# Altium schematic sheet 02_RNWF UART to Cloud Add on Board .SchDoc("02_RNWF UART to Cloud Add on Board ") (continued)
## Library data of the parts (continued)
R25-R28 R32 R34 R45 R47 R51 R53 R56 R58 R60 R63 R64 = RES2436: Manufacturer 1: Yageo; Manufacturer Part Number 1: RC0402FR-0722RL; Description_: RES TKF 22R 1% 1/16W SMD 0402; Dev Tools Status: Production; Owner: Balaji Narayana; Populated: YES; Power: 1/16W; Temperature Coefficient: 100ppm/C; Tolerance: 1%; Category: Resistor; Component Kind: Standard; ComponentLink1Description: SVN Datasheet; ComponentLink1URL: https://chn-vm-apps.microchip.com/mchprepo/parts/Datasheets/RC0402FR-0722RL.pdf; ComponentLink2Description: Local Datasheet; ComponentLink3Description: Open Symbol; ComponentLink4Description: Open Footprint; ComponentLink5Description: Open Footprint 2; ComponentLink6Description: Open Footprint 3; ComponentLink7Description: Open Footprint 4; Composition: TKF; Database Table Name: Resistor; Description: Resistor; Library Name: MCHP-CDB.DbLib; MCHP-ID: RES2436; Operating Temperature: -55C to +155C; Package: 0402; Quantity Override: 1; Revision: 1; Status: MCL Design; Supplier 1: Digi-Key; Supplier Part Number 1: 311-22.0LRTR-ND; Symbol: Resistor; Type: RES SMD; ComponentLink2URL: C:\ALTIUM_WORK\00001_MCHP-CDB\Datasheets\RC0402FR-0722RL.pdf; ComponentLink3URL: C:\ALTIUM_WORK\00001_MCHP-CDB\Library\LibraryRefs\Resistor.SchLib; ComponentLink4URL: C:\ALTIUM_WORK\00001_MCHP-CDB\Library\Footprints\RES_C_0402.PcbLib
R29 R30 = RSMT0439: Manufacturer 1: Vishay; Manufacturer Part Number 1: CRCW040210K0JNED; Description_: RES TKF 10k 5% 1/16W SMD 0402; Dev Tools Status: Production; Power: 1/16W; Temperature Coeffcient: 100ppm/C; Voltage: 50V; Category: Resistor; Component Kind: Standard; ComponentLink1Description: SVN Datasheet; ComponentLink1URL: https://chn-vm-apps.microchip.com/mchprepo/parts/Datasheets/PYu-RC0402_51_RoHS_L_6.pdf; ComponentLink2Description: Local Datasheet; ComponentLink3Description: Open Symbol; ComponentLink4Description: Open Footprint; ComponentLink5Description: Open Footprint 2; ComponentLink6Description: Open Footprint 3; ComponentLink7Description: Open Footprint 4; Composition: TKF; Database Table Name: Resistor; Description: Resistor; Library Name: MCHP-CDB.DbLib; Manufacturer 2: Yageo; Manufacturer Part Number 2: RC0402JR-0710KL; MCHP-ID: RSMT0439; Operating Temperature: -55C to +155C; Quantity Override: 1; Revision: 1; Status: MCL Design; Supplier 1: Digi-Key; Supplier 2: Digi-Key; Supplier Part Number 1: 541-10KJCT-ND; Supplier Part Number 2: 311-10KJRCT-ND; Symbol: Resistor; Type: RES SMD; ComponentLink2URL: C:\ALTIUM_WORK\00001_MCHP-CDB\Datasheets\PYu-RC0402_51_RoHS_L_6.pdf; ComponentLink3URL: C:\ALTIUM_WORK\00001_MCHP-CDB\Library\LibraryRefs\Resistor.SchLib; ComponentLink4URL: C:\ALTIUM_WORK\00001_MCHP-CDB\Library\Footprints\RES_C_0402.PcbLib
R36 = RSMT1019: Manufacturer 1: Panasonic; Manufacturer Part Number 1: ERJ-2RKF1002X; Description_: RES TKF 10k 1% 1/10W SMD 0402; Owner: Brent Lanphear; Populated: YES; Power: 1/10W; Temperature Coeffcient: 100ppm/C; Voltage: 50V; Category: Resistor; Component Kind: Standard; ComponentLink1Description: Datasheet; ComponentLink1URL: https://chn-vm-apps.microchip.com/mchprepo/parts/Datasheets/AOA0000CE2.pdf; Composition: TKF; Database Table Name: Resistor; Description: Resistor; Library Name: MCHP-CDB.DbLib; MCHP-ID: RSMT1019; Operating Temperature: -55C to +155C; Quantity Override: 1; Revision: 2; Status: Production; Supplier 1: Digi-Key; Supplier Part Number 1: P10.0KLCT-ND; Type: RES SMD
R44 = RSMT1357: Manufacturer 1: Yageo; Manufacturer Part Number 1: RC0201FR-071KL; Description_: RES TKF 1k 1% 1/20W SMD 0201; Dev Tools Status: Production; Owner: Ciprian Abramov; Populated: YES; Power: 1/20W; Restricted Sourcing: No; Temperature Coefficient: 200ppm/C; Voltage: 25V; Category: Resistor; Component Kind: Standard; ComponentLink1Description: SVN Datasheet; ComponentLink1URL: https://chn-vm-apps.microchip.com/mchprepo/parts/Datasheets/PYu-RC_Group_51_RoHS_L_11.pdf; ComponentLink2Description: Local Datasheet; ComponentLink3Description: Open Symbol; ComponentLink4Description: Open Footprint; ComponentLink5Description: Open Footprint 2; ComponentLink6Description: Open Footprint 3; ComponentLink7Description: Open Footprint 4; Composition: TKF; Database Table Name: Resistor; Description: Resistor; Library Name: MCHP-CDB.DbLib; MCHP-ID: RSMT1357; Operating Temperature: -55C to +125C; Quantity Override: 1; Revision: 1; Status: MCL Design; Supplier 1: Digi-Key; Supplier Part Number 1: 311-1KMCT-ND; Symbol: Resistor; Type: RES SMD; ComponentLink2URL: C:\ALTIUM_WORK\00001_MCHP-CDB\Datasheets\PYu-RC_Group_51_RoHS_L_11.pdf; ComponentLink3URL: C:\ALTIUM_WORK\00001_MCHP-CDB\Library\LibraryRefs\Resistor.SchLib; ComponentLink4URL: C:\ALTIUM_WORK\00001_MCHP-CDB\Library\Footprints\RES_C_0201.PcbLib
R62 = RES1552: Manufacturer 1: Panasonic Electronic Components; Manufacturer Part Number 1: ERJ-2RKF33R0X; Description_: RES TKF 33R 1% 1/10W SMD 0402; Dev Tools Status: Production; Owner: Sorin Stanoaia; Populated: YES; Power: 1/10W; Temperature Coefficient: 100ppm/C; Tolerance: 1%; Category: Resistor; Component Kind: Standard; ComponentLink1Description: SVN Datasheet; ComponentLink1URL: https://chn-vm-apps.microchip.com/mchprepo/parts/Datasheets/AOA0000CE2.pdf; ComponentLink2Description: Local Datasheet; ComponentLink3Description: Open Symbol; ComponentLink4Description: Open Footprint; ComponentLink5Description: Open Footprint 2; ComponentLink6Description: Open Footprint 3; ComponentLink7Description: Open Footprint 4; Composition: TKF; Database Table Name: Resistor; Description: Resistor; Library Name: MCHP-CDB.DbLib; MCHP-ID: RES1552; Operating Temperature: -55C to +155C; Package: 0402; Quantity Override: 1; Revision: 1; Status: MCL Design; Supplier 1: Digi-Key; Supplier Part Number 1: P33.0LCT-ND; Symbol: Resistor; Type: RES SMD; ComponentLink2URL: C:\ALTIUM_WORK\00001_MCHP-CDB\Datasheets\AOA0000CE2.pdf; ComponentLink3URL: C:\ALTIUM_WORK\00001_MCHP-CDB\Library\LibraryRefs\Resistor.SchLib; ComponentLink4URL: C:\ALTIUM_WORK\00001_MCHP-CDB\Library\Footprints\RES_C_0402.PcbLib
R67 = IND1735: Manufacturer 1: Murata Electronics; Manufacturer Part Number 1: BLM15AG121SN1D; DCR: 0.19R; Description_: FERRITE 120R @ 100MHz 0.55A 0.19R SMD 0402; Dev Tools Status: Design; Owner: Jojie Batislaon; Populated: YES; RMS Current: 0.55A; Test Frequency: 100MHz; Category: Inductor; Component Kind: Standard; ComponentLink1Description: SVN Datasheet; ComponentLink1URL: https://chn-vm-apps.microchip.com/mchprepo/parts/Datasheets/ENFA0018.pdf; ComponentLink2Description: Local Datasheet; ComponentLink3Description: Open Symbol; ComponentLink4Description: Open Footprint; ComponentLink5Description: Open Footprint 2; ComponentLink6Description: Open Footprint 3; ComponentLink7Description: Open Footprint 4; Database Table Name: Inductor; Description: Inductor; Library Name: MCHP-CDB.DbLib; MCHP-ID: IND1735; Operating Temperature: -55C to +125C; Package: 0402; Quantity Override: 1; Revision: 1; Status: MCL Design; Supplier 1: Digi-Key; Supplier Part Number 1: 490-1005-2-ND; Symbol: Inductor; Type: Ferrite Bead; ComponentLink2URL: C:\ALTIUM_WORK\00001_MCHP-CDB\Datasheets\ENFA0018.pdf; ComponentLink3URL: C:\ALTIUM_WORK\00001_MCHP-CDB\Library\LibraryRefs\Inductor.SchLib; ComponentLink4URL: C:\ALTIUM_WORK\00001_MCHP-CDB\Library\Footprints\IND_C_0402.PcbLib
R69 R88 R89 = RES2705: Manufacturer 1: Yageo; Manufacturer Part Number 1: RC0201FR-070RL; Current: 500mA; Description_: RES TKF 0R 1/20W SMD 0201; Dev Tools Status: Design; Owner: Jojie Batislaon; Populated: YES; Power: 1/20W; Restricted Sourcing: No; Voltage: 25V; Category: Resistor; Component Kind: Standard; ComponentLink1Description: SVN Datasheet; ComponentLink1URL: https://chn-vm-apps.microchip.com/mchprepo/parts/Datasheets/PYu-RC_Group_51_RoHS_L_11.pdf; ComponentLink2Description: Local Datasheet; ComponentLink3Description: Open Symbol; ComponentLink4Description: Open Footprint; ComponentLink5Description: Open Footprint 2; ComponentLink6Description: Open Footprint 3; ComponentLink7Description: Open Footprint 4; Composition: TKF; Database Table Name: Resistor; Description: Resistor; Library Name: MCHP-CDB.DbLib; MCHP-ID: RES2705; Operating Temperature: -55C to +125C; Quantity Override: 1; Revision: A; Status: MCL Design; Supplier 1: Digi-Key; Supplier Part Number 1: 311-0.0MTR-ND; Symbol: Resistor; Type: RES SMD; ComponentLink2URL: C:\ALTIUM_WORK\00001_MCHP-CDB\Datasheets\PYu-RC_Group_51_RoHS_L_11.pdf; ComponentLink3URL: C:\ALTIUM_WORK\00001_MCHP-CDB\Library\LibraryRefs\Resistor.SchLib; ComponentLink4URL: C:\ALTIUM_WORK\00001_MCHP-CDB\Library\Footprints\RES_C_0201.PcbLib
R71 R72 = RES1496: Manufacturer 1: Panasonic; Manufacturer Part Number 1: ERJ-2RKF2000X; Description_: RES TKF 200R 1% 1/10W SMD 0402; Dev Tools Status: Production; Populated: YES; Power: 1/10W; Temperature Coeffcient: 200ppm/C; Tolerance: 1%; Voltage: 50V; Category: Resistor; Component Kind: Standard; ComponentLink1Description: SVN Datasheet; ComponentLink1URL: https://chn-vm-apps.microchip.com/mchprepo/parts/Datasheets/AOA0000CE1.pdf; ComponentLink2Description: Local Datasheet; ComponentLink3Description: Open Symbol; ComponentLink4Description: Open Footprint; ComponentLink5Description: Open Footprint 2; ComponentLink6Description: Open Footprint 3; ComponentLink7Description: Open Footprint 4; Composition: TKF; Database Table Name: Resistor; Description: Resistor; Library Name: MCHP-CDB.DbLib; MCHP-ID: RES1496; Operating Temperature: -55C to +155C; Package: 0402; Quantity Override: 1; Revision: 1; Status: MCL Design; Supplier 1: Digi-Key; Supplier Part Number 1: P200LTR-ND; Symbol: Resistor; Type: RES SMD; ComponentLink2URL: C:\ALTIUM_WORK\00001_MCHP-CDB\Datasheets\AOA0000CE1.pdf; ComponentLink3URL: C:\ALTIUM_WORK\00001_MCHP-CDB\Library\LibraryRefs\Resistor.SchLib; ComponentLink4URL: C:\ALTIUM_WORK\00001_MCHP-CDB\Library\Footprints\RES_C_0402.PcbLib
R73-R77 = RSMT1040: Resistor; Manufacturer 1: Yageo; Manufacturer Part Number 1: RC0402JR-070RL; Description_: RES TKF 0R 1/16W SMD 0402; Dev Tools Status: Production; Owner: Sompoch Loboonlert; Power: 1/16W; Category: Resistor; Component Kind: Standard; ComponentLink1Description: SVN Datasheet; ComponentLink1URL: https://chn-vm-apps.microchip.com/mchprepo/parts/Datasheets/PYu-RC0402_51_RoHS_L_6.pdf; ComponentLink2Description: Local Datasheet; ComponentLink3Description: Open Symbol; ComponentLink4Description: Open Footprint; ComponentLink5Description: Open Footprint 2; ComponentLink6Description: Open Footprint 3; ComponentLink7Description: Open Footprint 4; Composition: TKF; Database Table Name: Resistor; Library Name: MCHP-CDB.DbLib; Manufacturer 2: Stackpole Electronics Inc; Manufacturer Part Number 2: RMCF0402ZT0R00; MCHP-ID: RSMT1040; Operating Temperature: -55C to +155C; Package: 0402; Quantity Override: 1; Revision: 3; Status: MCL Design; Supplier 1: Digi-Key; Supplier 2: Digi-Key; Supplier Part Number 1: 311-0.0JRCT-ND; Supplier Part Number 2: RMCF0402ZT0R00TR-ND; Symbol: Resistor; Type: RES SMD; ComponentLink2URL: C:\ALTIUM_WORK\00001_MCHP-CDB\Datasheets\PYu-RC0402_51_RoHS_L_6.pdf; ComponentLink3URL: C:\ALTIUM_WORK\00001_MCHP-CDB\Library\LibraryRefs\Resistor.SchLib; ComponentLink4URL: C:\ALTIUM_WORK\00001_MCHP-CDB\Library\Footprints\RES_C_0402.PcbLib
R78 = RES2436: Manufacturer 1: Yageo; Manufacturer Part Number 1: RC0402FR-0722RL; Description_: RES TKF 22R 1% 1/16W SMD 0402; Dev Tools Status: Production; Owner: Balaji Narayana; Populated: DNP; Power: 1/16W; Temperature Coefficient: 100ppm/C; Category: Resistor; Component Kind: Standard; ComponentLink1Description: SVN Datasheet; ComponentLink1URL: https://chn-vm-apps.microchip.com/mchprepo/parts/Datasheets/RC0402FR-0722RL.pdf; ComponentLink2Description: Local Datasheet; ComponentLink3Description: Open Symbol; ComponentLink4Description: Open Footprint; ComponentLink5Description: Open Footprint 2; ComponentLink6Description: Open Footprint 3; ComponentLink7Description: Open Footprint 4; Composition: TKF; Database Table Name: Resistor; Description: Resistor; Library Name: MCHP-CDB.DbLib; MCHP-ID: RES2436; Operating Temperature: -55C to +155C; Package: 0402; Quantity Override: 1; Revision: 1; Status: MCL Design; Supplier 1: Digi-Key; Supplier Part Number 1: 311-22.0LRTR-ND; Symbol: Resistor; Type: RES SMD; ComponentLink2URL: C:\ALTIUM_WORK\00001_MCHP-CDB\Datasheets\RC0402FR-0722RL.pdf; ComponentLink3URL: C:\ALTIUM_WORK\00001_MCHP-CDB\Library\LibraryRefs\Resistor.SchLib; ComponentLink4URL: C:\ALTIUM_WORK\00001_MCHP-CDB\Library\Footprints\RES_C_0402.PcbLib
R79 = RES1552: Manufacturer 1: Panasonic Electronic Components; Manufacturer Part Number 1: ERJ-2RKF33R0X; Description_: RES TKF 33R 1% 1/10W SMD 0402; Dev Tools Status: Production; Owner: Sorin Stanoaia; Power: 1/10W; Temperature Coeffcient: 100ppm/C; Tolerance: 1%; Category: Resistor; Component Kind: Standard; ComponentLink1Description: SVN Datasheet; ComponentLink1URL: https://chn-vm-apps.microchip.com/mchprepo/parts/Datasheets/AOA0000CE2.pdf; ComponentLink2Description: Local Datasheet; ComponentLink3Description: Open Symbol; ComponentLink4Description: Open Footprint; ComponentLink5Description: Open Footprint 2; ComponentLink6Description: Open Footprint 3; ComponentLink7Description: Open Footprint 4; Composition: TKF; Database Table Name: Resistor; Description: Resistor; Library Name: MCHP-CDB.DbLib; MCHP-ID: RES1552; Operating Temperature: -55C to +155C; Package: 0402; Quantity Override: 1; Revision: 1; Status: MCL Design; Supplier 1: Digi-Key; Supplier Part Number 1: P33.0LCT-ND; Symbol: Resistor; Temperature Coefficient: 100ppm/C; Type: RES SMD; ComponentLink2URL: C:\ALTIUM_WORK\00001_MCHP-CDB\Datasheets\AOA0000CE2.pdf; ComponentLink3URL: C:\ALTIUM_WORK\00001_MCHP-CDB\Library\LibraryRefs\Resistor.SchLib; ComponentLink4URL: C:\ALTIUM_WORK\00001_MCHP-CDB\Library\Footprints\RES_C_0402.PcbLib
R80 = RES0191: Resistor; Manufacturer 1: Panasonic; Manufacturer Part Number 1: ERJ-3GEYJ103V; Description_: RES TKF 10k 5% 1/10W SMD 0603; Dev Tools Status: Production; Populated: YES; Power: 1/10W; Temperature Coeffcient: 200ppm/C; Voltage: 75V; Category: Resistor; Component Kind: Standard; ComponentLink1Description: SVN Datasheet; ComponentLink1URL: https://chn-vm-apps.microchip.com/mchprepo/parts/Datasheets/AOA0000CE1.pdf; ComponentLink2Description: Local Datasheet; ComponentLink3Description: Open Symbol; ComponentLink4Description: Open Footprint; ComponentLink5Description: Open Footprint 2; ComponentLink6Description: Open Footprint 3; ComponentLink7Description: Open Footprint 4; Composition: TKF; Database Table Name: Resistor; Library Name: MCHP-CDB.DbLib; Manufacturer 2: Yageo; Manufacturer 3: NIC Components; Manufacturer Part Number 2: RC0603JR-0710KL; Manufacturer Part Number 3: NRC06J103TRF; MCHP-ID: RES0191; Operating Temperature: -55C to +155C; Quantity Override: 1; Revision: 2; Status: MCL Design; Supplier 1: Digi-Key; Supplier 2: Digi-Key; Supplier 3: Digi-Key; Supplier 4: NIC Components; Supplier Part Number 1: P10KGCT-ND; Supplier Part Number 2: P10KGTR-ND; Supplier Part Number 3: 311-10KGRTR-ND; Supplier Part Number 4: NRC06J103TRF; Symbol: Resistor; Type: RES SMD; ComponentLink2URL: C:\ALTIUM_WORK\00001_MCHP-CDB\Datasheets\AOA0000CE1.pdf; ComponentLink3URL: C:\ALTIUM_WORK\00001_MCHP-CDB\Library\LibraryRefs\Resistor.SchLib; ComponentLink4URL: C:\ALTIUM_WORK\00001_MCHP-CDB\Library\Footprints\RES_C_0603.PcbLib
R81 R85 = RES2977: Manufacturer 1: Panasonic Electronic Components; Manufacturer Part Number 1: ERJ-2GEJ122X; Description_: RES TKF 1.2k 5% 0.1W SMD 0402; Dev Tools Status: Design; Owner: Balaji Narayana; Populated: YES; Power: 1/10W; Temperature Coefficient: 200ppm/C; Category: Resistor; Component Kind: Standard; ComponentLink1Description: SVN Datasheet; ComponentLink1URL: https://chn-vm-apps.microchip.com/mchprepo/parts/Datasheets/ERJ-2GEJ122X.pdf; ComponentLink2Description: Local Datasheet; ComponentLink3Description: Open Symbol; ComponentLink4Description: Open Footprint; ComponentLink5Description: Open Footprint 2; ComponentLink6Description: Open Footprint 3; ComponentLink7Description: Open Footprint 4; Composition: TKF; Database Table Name: Resistor; Description: Resistor; Library Name: MCHP-CDB.DbLib; MCHP-ID: RES2977; Operating Temperature: -55C to +155C; Quantity Override: 1; Revision: 1; Status: MCL Design; Supplier 1: Digikey; Supplier Part Number 1: P1.2KJTR-ND; Symbol: Resistor; Type: RES SMD; ComponentLink2URL: C:\ALTIUM_WORK\00001_MCHP-CDB\Datasheets\ERJ-2GEJ122X.pdf; ComponentLink3URL: C:\ALTIUM_WORK\00001_MCHP-CDB\Library\LibraryRefs\Resistor.SchLib; ComponentLink4URL: C:\ALTIUM_WORK\00001_MCHP-CDB\Library\Footprints\RES_C_0402.PcbLib
R82 R83 = RSMT1019: Resistor; Manufacturer 1: Panasonic; Manufacturer Part Number 1: ERJ-2RKF1002X; Description_: RES TKF 10k 1% 1/10W SMD 0402; Dev Tools Status: Production; Owner: Brent Lanphear; Populated: YES; Power: 1/10W; Temperature Coefficient: 100ppm/C; Voltage: 50V; Category: Resistor; Component Kind: Standard; ComponentLink1Description: SVN Datasheet; ComponentLink1URL: https://chn-vm-apps.microchip.com/mchprepo/parts/Datasheets/AOA0000CE2.pdf; ComponentLink2Description: Local Datasheet; ComponentLink3Description: Open Symbol; ComponentLink4Description: Open Footprint; ComponentLink5Description: Open Footprint 2; ComponentLink6Description: Open Footprint 3; ComponentLink7Description: Open Footprint 4; Composition: TKF; Database Table Name: Resistor; Library Name: MCHP-CDB.DbLib; MCHP-ID: RSMT1019; Operating Temperature: -55C to +155C; Quantity Override: 1; Revision: 2; Status: MCL Design; Supplier 1: Digi-Key; Supplier Part Number 1: P10.0KLCT-ND; Symbol: Resistor; Type: RES SMD; ComponentLink2URL: C:\ALTIUM_WORK\00001_MCHP-CDB\Datasheets\AOA0000CE2.pdf; ComponentLink3URL: C:\ALTIUM_WORK\00001_MCHP-CDB\Library\LibraryRefs\Resistor.SchLib; ComponentLink4URL: C:\ALTIUM_WORK\00001_MCHP-CDB\Library\Footprints\RES_C_0402.PcbLib
TP2 TP3 = CON1956: Test Point; Database Table Name: Connector; Description_: CON TP PAD PCB SMD D0.8; Dev Tools Status: Design; Owner: Mark Loper; Populated: YES; Category: Connector; ComponentLink1Description: SVN Datasheet; ComponentLink2Description: Local Datasheet; ComponentLink3Description: Open Symbol; ComponentLink4Description: Open Footprint; ComponentLink5Description: Open Footprint 2; ComponentLink6Description: Open Footprint 3; ComponentLink7Description: Open Footprint 4; Library Name: MCHP-CDB.DbLib; MCHP-ID: CON1956; Quantity Override: 1; Revision: 1; Status: MCL Design; Symbol: Test Point No BOM; Type: Test Point No BOM; ComponentLink3URL: C:\ALTIUM_WORK\00001_MCHP-CDB\Library\LibraryRefs\Test Point No BOM.SchLib; ComponentLink4URL: C:\ALTIUM_WORK\00001_MCHP-CDB\Library\Footprints\CON_TP_0.8dia_SMD.PcbLib
U1 = MIC2631: LDO ADJ; Manufacturer 1: Microchip; Manufacturer Part Number 1: MCP1727T-ADJE/MF; Database Table Name: MCHP-Analog; Description_: MCHP ANALOG LDO 0.8V-5V MCP1727T-ADJE/MF DFN-8; Dev Tools Status: Production; ForceMinOrderQtyMult: True; LeadContent: Pb-FREE; LeadCount: 8; MAPS Description: 1.5A LOW VOLTAGE LDO; MinOrderQty: 1; MPC: GEAG1YA7XADJ; PackageWidth: 3x3x0.9mm; PackingMedia: T/R; Populated: YES; Stage: REL; tblDeviceFamilyID: 1674; TempRange: -40C to +125C; Category: MCHP-Analog; Component Kind: Standard; ComponentLink1Description: SVN Datasheet; ComponentLink1URL: http://www.microchip.com/wwwproducts/MCP1727; ComponentLink2Description: Local Datasheet; ComponentLink3Description: Open Symbol; ComponentLink4Description: Open Footprint; ComponentLink5Description: Open Footprint 2; ComponentLink6Description: Open Footprint 3; ComponentLink7Description: Open Footprint 4; IntroductionDate: 2006-08-02; Library Name: MCHP-CDB.DbLib; Manufacturer 2: Microchip; Manufacturer Part Number 2: MCP1727-ADJE/MF; MCHP-ID: MIC2631; PackageType: DFN; Quantity Override: 1; Revision: 0; Status: MCL Design; Supplier 1: Microchip; Supplier 2: Microchip; Supplier Part Number 1: MCP1727T-ADJE/MF; Supplier Part Number 2: MCP1727-ADJE/MF; Symbol: MCP172X ADJ DFN-8; Type: LDO; ComponentLink3URL: C:\ALTIUM_WORK\00001_MCHP-CDB\Library\LibraryRefs\MCP172X ADJ DFN-8.SchLib; ComponentLink4URL: C:\ALTIUM_WORK\00001_MCHP-CDB\Library\Footprints\DFN-8_3x3.PcbLib
U2 = MIC6326: USB 2.0 to UART Protocol Conv with GPIO QFN20; Manufacturer: Microchip Technology; Database Table Name: MCHP-Interface; Description_: MCHP INTERFACE USB UART MCP2200-I/MQ QFN-20; Dev Tools Status: Production; EEPROM: 256; ForceMinOrderQtyMult: False; GPIO: 8; LeadContent: Pb-FREE; LeadCount: 20; MAPS Description: USB to UART Protocol Converter; MinOrderQty: 1; MPC: LEAG17P8X026; OperatingVoltageMax: 5.5; OperatingVoltageMin: 3.0; oscillator: External; Owner: Jamus Griego; PackageWidth: 5x5x0.9mm; PackingMedia: TUBE; Populated: YES; RxBuffers: 128; Stage: REL; tblDeviceFamilyID: 2705; TempRange: -40C to +85C; USB: Full Speed; usbcompliant: USB 2.0; Voltage: 3V ~ 5.5V; Category: MCHP-Interface; Component Kind: Standard; ComponentLink1Description: SVN Datasheet; ComponentLink1URL: http://www.microchip.com/wwwproducts/MCP2200; ComponentLink2Description: Local Datasheet; ComponentLink3Description: Open Symbol; ComponentLink4Description: Open Footprint; ComponentLink5Description: Open Footprint 2; ComponentLink6Description: Open Footprint 3; ComponentLink7Description: Open Footprint 4; Features: USB to UART; FIFO's: -; IntroductionDate: 2010-03-01; Library Name: MCHP-CDB.DbLib; Manufacturer 1: Microchip Technology; Manufacturer Part Number 1: MCP2200-I/MQ; MCHP-ID: MIC6326; Mounting Type: Surface Mount; Number of Channels: 8; Package Size: 20-VQFN, Exposed Pad; PackageType: QFN; Packaging: Tube; Pricing 1: 1=2.3, 10=2.1, 25=1.75, 100=1.59 (USD); Quantity Override: 1; Revision: 1; RoHS: RoHS Compliant; Status: MCL Design; Stock 1: 839; Supplier 1: Digi-Key; Supplier Part Number 1: MCP2200-I/MQ-ND; Symbol: MCP2200 QFN-20; TxBuffers: 128; Type: USB; ComponentLink3URL: C:\ALTIUM_WORK\00001_MCHP-CDB\Library\LibraryRefs\MCP2200 QFN-20.SchLib; ComponentLink4URL: C:\ALTIUM_WORK\00001_MCHP-CDB\Library\Footprints\QFN-20_5X5.PcbLib
U3 = MIC9887: Manufacturer 1: Microchip Technology; Database Table Name: MCHP-Wireless; Description_: PIC32MZ1025W1, PCB Ant, Trust & GO, firmware version 001; Dev Tools Status: Production; Owner: Balaji Narayana; Populated: YES; Restricted Sourcing: No; Category: MCHP-Wireless; Component Kind: Standard; ComponentLink1Description: SVN Datasheet; ComponentLink1URL: https://www.microchip.com/sitesearch/search/Product%20and%20Development%20Tools/RNWF11PC-I100; ComponentLink2Description: Local Datasheet; ComponentLink3Description: Open Symbol; ComponentLink4Description: Open Footprint; ComponentLink5Description: Open Footprint 2; ComponentLink6Description: Open Footprint 3; ComponentLink7Description: Open Footprint 4; Library Name: MCHP-CDB.DbLib; MCHP-ID: MIC9887; Operating Temperature: -40C to +85C; Quantity Override: 1; Revision: 1; Status: MCL Design; Supplier 1: Microchip Technology; Supplier Part Number 1: RNWF11PC-I100; Symbol: MODULE RNWF11PC-I; Type: Wi-Fi; ComponentLink3URL: C:\ALTIUM_WORK\00001_MCHP-CDB\Library\LibraryRefs\MODULE RNWF11PC-I.SchLib; ComponentLink4URL: C:\ALTIUM_WORK\00001_MCHP-CDB\Library\Footprints\MOD_RNWF11PC-I.PcbLib
U4 = MIC9023: Manufacturer 1: Microchip Technology; Manufacturer Part Number 1: ATECC608B-MAHA6-S; Database Table Name: MCHP-Interface; Description_: MCHP INTERFACE SECURITY I2C ATECC608B-TFLXTLS UDFN-8; Dev Tools Status: Production; Owner: James Boomer; Populated: YES; Symbol: ATECC608B-MAHDA UDFN-8; Category: MCHP-Interface; Component Kind: Standard; ComponentLink1Description: SVN Datasheet; ComponentLink1URL: https://www.microchip.com/sitesearch/search/Product%20and%20Development%20Tools/ATECC608B-MAHA6-S; ComponentLink2Description: Local Datasheet; ComponentLink3Description: Open Symbol; ComponentLink4Description: Open Footprint; ComponentLink5Description: Open Footprint 2; ComponentLink6Description: Open Footprint 3; ComponentLink7Description: Open Footprint 4; Library Name: MCHP-CDB.DbLib; MCHP-ID: MIC9023; Operating Temperature: -40C to +85C; Quantity Override: 1; Revision: 4; Status: MCL Design; Supplier 1: Microchip Technology; Supplier Part Number 1: ATECC608B-MAHA6-S; ComponentLink3URL: C:\ALTIUM_WORK\00001_MCHP-CDB\Library\LibraryRefs\ATECC608B-MAHDA UDFN-8.SchLib; ComponentLink4URL: C:\ALTIUM_WORK\00001_MCHP-CDB\Library\Footprints\UDFN-8_2X3_EP1.5X1.3.PcbLib
U5 = MIC6217: SQI Serial Flash; Manufacturer 1: Microchip Technology; Manufacturer Part Number 1: SST26VF032B-104I/SM; Database Table Name: MCHP-Memory; Description_: MCHP MEMORY SERIAL FLASH SST26VF032B-104I/SM SOIJ-8; Dev Tools Status: Production; Endurance: 100,000; ForceMinOrderQtyMult: False; LeadContent: Pb-FREE; LeadCount: 8; MAPS Description: 32Mbit SQI flash; MaxClockFreq: 104 MHz; MemorySize: 32Mb; MinOrderQty: 0; MPC: S01017C3XA23; OpVoltMax: 3.6; OpVoltMin: 2.3; Owner: Sompoch Loboonlert; PackageWidth: .208in; PackingMedia: TUBE; PageSize_B: 256; Populated: YES; Stage: REL; TempRange: -40C to +85C; WPScheme: Full Array; Category: MCHP-Memory; Component Kind: Standard; ComponentLink1Description: SVN Datasheet; ComponentLink1URL: http://www.microchip.com/wwwproducts/SST26VF032B; ComponentLink2Description: Local Datasheet; ComponentLink3Description: Open Symbol; ComponentLink4Description: Open Footprint; ComponentLink5Description: Open Footprint 2; ComponentLink6Description: Open Footprint 3; ComponentLink7Description: Open Footprint 4; IntroductionDate: 2015-02-03; Library Name: MCHP-CDB.DbLib; MCHP-ID: MIC6217; PackageType: SOIJ; Quantity Override: 1; Revision: 1; Status: MCL Design; Supplier 1: Microchip Technology; Supplier Part Number 1: SST26VF032B-104I/SM; Symbol: SST26VFXXX SOIC-8_WSON-8_SOIJ-8; Type: Serial Flash; ComponentLink3URL: C:\ALTIUM_WORK\00001_MCHP-CDB\Library\LibraryRefs\SST26VFXXX SOIC-8_WSON-8_SOIJ-8.SchLib; ComponentLink4URL: C:\ALTIUM_WORK\00001_MCHP-CDB\Library\Footprints\SOIJ-8.PcbLib
X1 = OSC1232: Resonator; Manufacturer 1: Murata Electronics; Manufacturer Part Number 1: CSTNE12M0GH5L000R0; Database Table Name: Crystal-Oscillator; Description_: RESONATOR 12MHz 0.07% SMD CSTNE 3-SMD; Dev Tools Status: Design; Load Capacitance: 33pF; Owner: Ranjana Kumari; Populated: YES; Tolerance: 0.07%; Category: Crystal/Oscillator; Component Kind: Standard; ComponentLink1Description: SVN Datasheet; ComponentLink1URL: https://chn-vm-apps.microchip.com/mchprepo/parts/Datasheets/CSTNE12M0GH5L000R0.pdf; ComponentLink2Description: Local Datasheet; ComponentLink3Description: Open Symbol; ComponentLink4Description: Open Footprint; ComponentLink5Description: Open Footprint 2; ComponentLink6Description: Open Footprint 3; ComponentLink7Description: Open Footprint 4; Library Name: MCHP-CDB.DbLib; MCHP-ID: OSC1232; Operating Temperature: -40C to +85C; Package: 3-SMD; Quantity Override: 1; Revision: 1; Status: MCL Design; Supplier 1: Digi-Key; Supplier Part Number 1: 490-17947-1-ND; Symbol: Resonator 3 Pins; Type: Resonator; ComponentLink2URL: C:\ALTIUM_WORK\00001_MCHP-CDB\Datasheets\CSTNE12M0GH5L000R0.pdf; ComponentLink3URL: C:\ALTIUM_WORK\00001_MCHP-CDB\Library\LibraryRefs\Resonator 3 Pins.SchLib; ComponentLink4URL: C:\ALTIUM_WORK\00001_MCHP-CDB\Library\Footprints\RESONATOR_CSTCE-G_L3.2W1.3H0.8.PcbLib
X2 = OSC1042: Crystal; Manufacturer 1: Abracon Corporation; Manufacturer Part Number 1: ASEMB-50.000MHZ-LC-T; Database Table Name: Crystal-Oscillator; Description_: OSCILLATOR 50MHz 1.8V-3.3V SMD ASEMB; Dev Tools Status: Production; Owner: Randolph Sobrepena; Supply Current: 16mA; Symbol: Oscillator ASEMB; Voltage: 1.8 V - 3.3 V; Category: Crystal/Oscillator; Component Kind: Standard; ComponentLink1Description: SVN Datasheet; ComponentLink1URL: https://chn-vm-apps.microchip.com/mchprepo/parts/Datasheets/ASEMB.pdf; ComponentLink2Description: Local Datasheet; ComponentLink3Description: Open Symbol; ComponentLink4Description: Open Footprint; ComponentLink5Description: Open Footprint 2; ComponentLink6Description: Open Footprint 3; ComponentLink7Description: Open Footprint 4; Library Name: MCHP-CDB.DbLib; Manufacturer 2: Epson; Manufacturer 3: Siward Crystal Technology Co. Ltd.; Manufacturer Part Number 2: SG3225CAN 50.0000M-TDBA3; Manufacturer Part Number 3: SCO-B452; MCHP-ID: OSC1042; Operating Temperature: -40C to 85C; Package: DFN-4; Quantity Override: 1; Revision: 5; Status: MCL Design; Supplier 1: Digi-Key; Supplier 2: Digi-Key; Supplier 3: Siward Crystal Technology Co. Ltd.; Supplier Part Number 1: 535-11758-1-ND; Supplier Part Number 2: 114-SG3225CAN50.0000M-TDBA3TR-ND; Supplier Part Number 3: SCO-B452; Type: Oscillator; ComponentLink2URL: C:\ALTIUM_WORK\00001_MCHP-CDB\Datasheets\ASEMB.pdf; ComponentLink3URL: C:\ALTIUM_WORK\00001_MCHP-CDB\Library\LibraryRefs\Oscillator ASEMB.SchLib; ComponentLink4URL: C:\ALTIUM_WORK\00001_MCHP-CDB\Library\Footprints\OSCILLATOR_ASEMB.PcbLib
Y1 = OSC1224: Crystal; Manufacturer 1: Epson; Manufacturer Part Number 1: FC-12M 32.7680KA-A5; Database Table Name: Crystal-Oscillator; Description_: CRYSTAL 32.768KHZ 12.5PF SMD 2-SMD, No Lead; Dev Tools Status: Production; Load Capacitance: 12.5PF; Owner: Ranjana Kumari; Populated: YES; Restricted Sourcing: No; Category: Crystal/Oscillator; Component Kind: Standard; ComponentLink1Description: SVN Datasheet; ComponentLink1URL: https://chn-vm-apps.microchip.com/mchprepo/parts/Datasheets/EPSON_FC-12M 32.7680KA-A5.pdf; ComponentLink2Description: Local Datasheet; ComponentLink3Description: Open Symbol; ComponentLink4Description: Open Footprint; ComponentLink5Description: Open Footprint 2; ComponentLink6Description: Open Footprint 3; ComponentLink7Description: Open Footprint 4; Library Name: MCHP-CDB.DbLib; MCHP-ID: OSC1224; Operating Temperature: -40C to +85C; Package: 2-SMD, No Lead; Quantity Override: 1; Revision: 1; Status: MCL Design; Supplier 1: Digi-Key; Supplier Part Number 1: SER4080CT-ND; Symbol: Crystal 2 Pins; Type: Crystal; ComponentLink2URL: C:\ALTIUM_WORK\00001_MCHP-CDB\Datasheets\EPSON_FC-12M 32.7680KA-A5.pdf; ComponentLink3URL: C:\ALTIUM_WORK\00001_MCHP-CDB\Library\LibraryRefs\Crystal 2 Pins.SchLib; ComponentLink4URL: C:\ALTIUM_WORK\00001_MCHP-CDB\Library\Footprints\CRYSTAL_FC-12M_L2.05W1.2H0.6.PcbLib
